# Altium schematic sheet SAR_ADC.SchDoc(SAR_ADC)
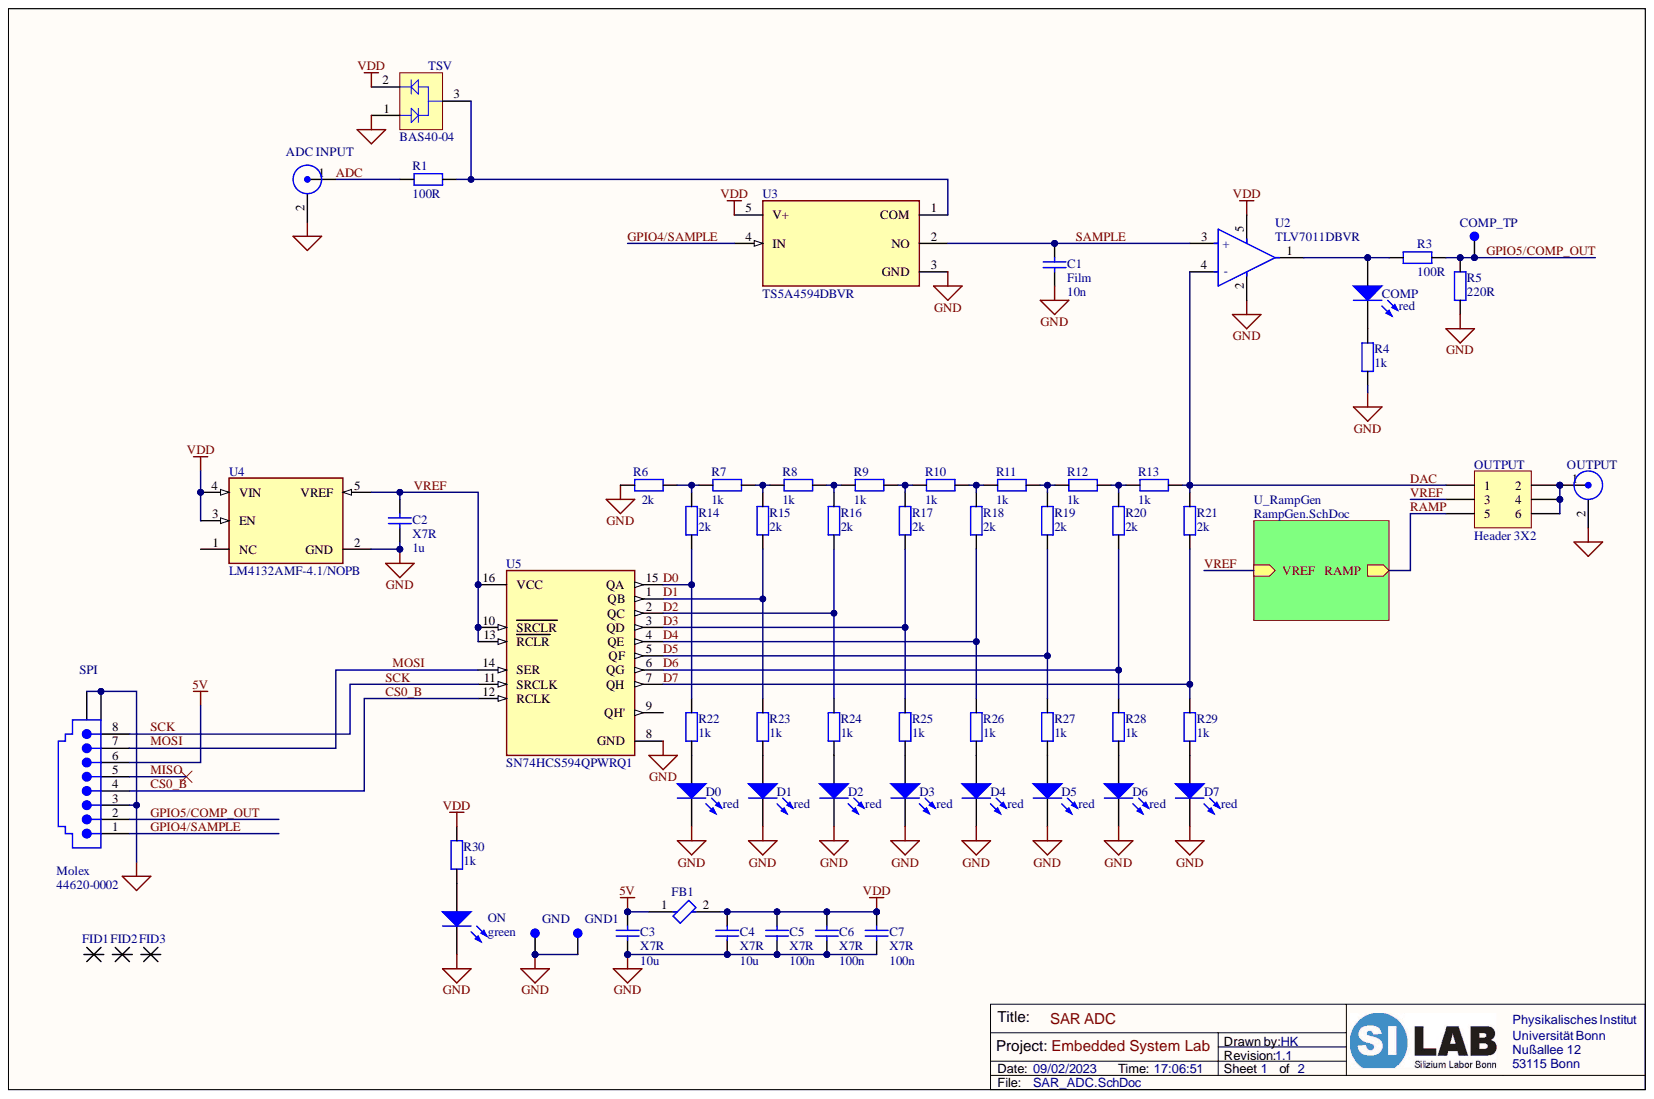
[diagram p0: SAR_ADC.SchDoc]

## Parts
ADC_INPUT  -  [LEMO horiz.]  (value not drawn)
C1  Film  value 10n  [C2220_imp_N]
C2  X7R  value 1u  [C0603_imp_N]
C3  X7R  value 10u  [C0603_imp_N]
C4  X7R  value 10u  [C0603_imp_N]
C5  X7R  value 100n  [C0603_imp_N]
C6  X7R  value 100n  [C0603_imp_N]
C7  X7R  value 100n  [C0603_imp_N]
COMP  red  [0603_A]
COMP_TP  -  [Testpoint 1.0mm]  (value not drawn)
D0  red  [0603_A]
D1  red  [0603_A]
D2  red  [0603_A]
D3  red  [0603_A]
D4  red  [0603_A]
D5  red  [0603_A]
D6  red  [0603_A]
D7  red  [0603_A]
FB1  BLM18PG121SN1D  [FP-BLM18-0_15-t0_8-IPC_A]  (value not drawn)
FID1  xxx  [Fiducial]  (value not drawn)
FID2  xxx  [Fiducial]  (value not drawn)
FID3  xxx  [Fiducial]  (value not drawn)
GND  -  [Testpoint 1.0mm]  (value not drawn)
GND1  -  [Testpoint 1.0mm]  (value not drawn)
ON  green  [0603_A]
OUTPUT  -  [LEMO horiz.]  (value not drawn)
OUTPUT  Header 3X2  [HDR2X3]
R1  R  value 100R  [R0603_imp_N]
R3  R  value 100R  [R0603_imp_N]
R4  R  value 1k  [R0603_imp_N]
R5  R  value 220R  [R0603_imp_N]
R6  R  value 2k  [R0603_imp_N]
R7  R  value 1k  [R0603_imp_N]
R8  R  value 1k  [R0603_imp_N]
R9  R  value 1k  [R0603_imp_N]
R10  R  value 1k  [R0603_imp_N]
R11  R  value 1k  [R0603_imp_N]
R12  R  value 1k  [R0603_imp_N]
R13  R  value 1k  [R0603_imp_N]
R14  R  value 2k  [R0603_imp_N]
R15  R  value 2k  [R0603_imp_N]
R16  R  value 2k  [R0603_imp_N]
R17  R  value 2k  [R0603_imp_N]
R18  R  value 2k  [R0603_imp_N]
R19  R  value 2k  [R0603_imp_N]
R20  R  value 2k  [R0603_imp_N]
R21  R  value 2k  [R0603_imp_N]
R22  R  value 1k  [R0603_imp_N]
R23  R  value 1k  [R0603_imp_N]
R24  R  value 1k  [R0603_imp_N]
R25  R  value 1k  [R0603_imp_N]
R26  R  value 1k  [R0603_imp_N]
R27  R  value 1k  [R0603_imp_N]
R28  R  value 1k  [R0603_imp_N]
R29  R  value 1k  [R0603_imp_N]
R30  R  value 1k  [R0603_imp_N]
SPI  Comment_1: Molex  [44620-0002]  (hidden comment: -)
TSV  BAS40-04  [INF-SOT23_L]
U2  TLV7011DBVR  [FP-DBV0005A-MFG]
U3  TS5A4594DBVR  [FP-DBV0005A-IPC_A]
U4  LM4132AMF-4.1/NOPB  [FP-DBV0005A-IPC_A]
U5  SN74HCS594QPWRQ1  [FP-PW0016A-IPC_A]

## Nets
5V: C3.1 FB1.1 SPI.6
GND: ADC_INPUT.2 C1.2 C2.2 C3.2 C4.2 C5.2 C6.2 C7.2 D0.1 D1.1 D2.1 D3.1 D4.1 D5.1 D6.1 D7.1 GND.1 GND1.1 ON.1 OUTPUT.2 R4.2 R5.2 R6.2 SPI.3 SPI.MH1 SPI.MH2 TSV.1 U2.2 U3.3(GND) U4.2(GND) U5.8(GND)
VDD: C4.1 C5.1 C6.1 C7.1 FB1.2 R30.2 TSV.2 U2.5 U3.5(V+) U4.3(EN) U4.4(VIN)
ADC: ADC_INPUT.1 R1.2
CS0_B: SPI.4 U5.12(RCLK)
D0: R14.2 R22.1 U5.15(QA)
D1: R15.1 R23.2 U5.1(QB)
D2: R16.2 R24.1 U5.2(QC)
D3: R17.2 R25.1 U5.3(QD)
D4: R18.2 R26.1 U5.4(QE)
D5: R19.2 R27.1 U5.5(QF)
D6: R20.2 R28.1 U5.6(QG)
D7: R21.2 R29.1 U5.7(QH)
DAC: OUTPUT.1 R13.1 R21.1 U2.4(-)
GPIO4/SAMPLE: SPI.1 U3.4(IN)
GPIO5/COMP_OUT: COMP_TP.1 R3.2 R5.1 SPI.2
MISO: SPI.5
MOSI: SPI.7 U5.14(SER)
RAMP: OUTPUT.5
SAMPLE: C1.1 U2.3(+) U3.2(NO)
SCK: SPI.8 U5.11(SRCLK)
VREF: C2.1 OUTPUT.3 U4.5(VREF) U5.10(SRCLR) U5.13(RCLR) U5.16(VCC)
NetCOMP_1: COMP.1 R4.1
NetCOMP_2: COMP.2 R3.1 U2.1
NetD0_2: D0.2 R22.2
NetD1_2: D1.2 R23.1
NetD2_2: D2.2 R24.2
NetD3_2: D3.2 R25.2
NetD4_2: D4.2 R26.2
NetD5_2: D5.2 R27.2
NetD6_2: D6.2 R28.2
NetD7_2: D7.2 R29.2
NetON_2: ON.2 R30.1
NetOUTPUT_1: OUTPUT.1 OUTPUT.2 OUTPUT.4 OUTPUT.6
NetR1_1: R1.1 TSV.3 U3.1(COM)
NetR6_1: R6.1 R7.1 R14.1
NetR7_2: R7.2 R8.2 R15.2
NetR8_1: R8.1 R9.2 R16.1
NetR9_1: R9.1 R10.2 R17.1
NetR10_1: R10.1 R11.2 R18.1
NetR11_1: R11.1 R12.2 R19.1
NetR12_1: R12.1 R13.2 R20.1
NetU4_1: U4.1(NC)
NetU5_9: U5.9(QH')

## Library data of the parts
ADC_INPUT OUTPUT = LEMO coax: LEMO/BNC Buchse, aufrecht od. gewinkelt; Class: Connector; Comment_1: LEMO; Type_1: EPL.00.250.NTN; Component Kind: Standard; Constraints: -; HelpURL: -; LatestRevisionDate: 24-Sep-2008; PackageDescription: -; Value: -; Library Name: Silab_Lib.IntLib; Publisher: Silab C.H.
C1-C7 = C: Capacitor; Class: Passive; Constraints: 6.3V; Type_1: SMD Chip Capacitor; Comment_1: -; Component Kind: Standard; HelpURL: -; LatestRevisionDate: 27-Nov-2007; PackageDescription: -; Signal Integrity: Capacitor; Simulation: Capacitor; Library Name: Silab_Lib.IntLib; Publisher: Silab J.S.
COMP D0-D7 = CMP-1426-00001-1: SMD mono-color Chip LED, WL-SMCW, Red; Manufacturer: Wuerth Elektronik; PartNumber: 150060RS75000; Color: Red; Component Kind: Standard; ComponentLink1Description: Manufacturer URL; ComponentLink1URL: http://www.we-online.com; ComponentLink2Description: Datasheet; ComponentLink2URL: http://katalog.we-online.com/led/datasheet/150060RS75000.pdf; Library Name: Altium Content Vault; PackageReference: 0603_A
COMP_TP GND GND1 = Testpoint_gefüllt: Solderpad, Einzelner Kontakt; Class: Connector; Comment_1: -; Component Kind: Standard; Constraints: -; HelpURL: -; LatestRevisionDate: 24-Sep-2008; PackageDescription: -; Type_1: -; Value: -; Library Name: Silab_Lib.IntLib; Publisher: Silab C.H.
FB1 = CMP-0686-00557-7: Chip Ferrite Bead, 0603, 120Ω @ 100MHz, 0.05Ω, 25%, 2A; DC Resistance (DCR): 50mR; Impedance: 120R; Material: Steel; Number of Circuits: 1; Shielding: Unshielded; Case Code (Imperial): 0603; Case Code (Metric): 1608; Case/Package: 0603; Component Kind: Standard; Core Material: Ferrite; Current Rating: 2A; DC Current: 2A; Depth: 0.8mm; Frequency: 100MHz; Height: 0.8mm; Lead Free: Lead Free; Length: 1.6mm; Library Name: Altium Content Vault; Max Current Rating: 2A; Max DC Current: 2A; Max Operating Temperature: 125°C; Min Operating Temperature: -55°C; Number of Lines: 1; Number of Pins: 2; Package Quantity: 1; Packaging: Tape and Reel; Radiation Hardening: No; REACH SVHC: No SVHC; RoHS Compliant: Yes; Termination: SMD/SMT; Test Frequency: 100MHz; Tolerance: 25%; Width: 0.8mm
FID1-FID3 = Fiducial: Class: Passive; Comment_1: xxx; Component Kind: Standard; Constraints: xxx; Description: Fiducial; LatestRevisionDate: 09-Aug-2013; PackageDescription: xxx; Type_1: xxx; Value: xxx; HelpURL: http://www.   ...  or    X:\DXP\Datasheets\ ...; Library Name: Silab_Lib.IntLib; Publisher: Silab VF
ON = CMP-1426-00002-1: SMD mono-color Chip LED, WL-SMCW, Green; Manufacturer: Wuerth Elektronik; PartNumber: 150060GS75000; Color: Green; Component Kind: Standard; ComponentLink1Description: Manufacturer URL; ComponentLink1URL: http://www.we-online.com; ComponentLink2Description: Datasheet; ComponentLink2URL: http://katalog.we-online.com/led/datasheet/150060GS75000.pdf; Library Name: Altium Content Vault; PackageReference: 0603_A
OUTPUT = Header 3X2: Header, 3-Pin, Dual row; Component Kind: Standard; LatestRevisionDate: 17-Jul-2002; LatestRevisionNote: Re-released for DXP Platform.; Library Name: Miscellaneous Connectors.IntLib; Signal Integrity: Connector; Publisher: Altium Limited
R1 R3-R6 R14-R30 = R: SMD Chip Resistor; Class: Passive; Constraints: 1%; Comment_1: -; Component Kind: Standard; HelpURL: -; LatestRevisionDate: 27-Nov-2007; PackageDescription: -; Signal Integrity: Resistor; Simulation: Resistor; Type_1: SMD Chip Resistor; Library Name: Silab_Lib.IntLib; Publisher: Silab J.S.
R7-R13 = R: SMD Chip Resistor; Class: Passive; Constraints: 1%; Value: 1k; Comment_1: -; Component Kind: Standard; HelpURL: -; LatestRevisionDate: 27-Nov-2007; PackageDescription: -; Signal Integrity: Resistor; Simulation: Resistor; Type_1: SMD Chip Resistor; Library Name: Silab_Lib.IntLib; Publisher: Silab J.S.
SPI = RJ-45 Buchse: Modular Jack, Right Angle RJ-45, Low Profile, Inverted, with all Panel Grounding Tabs, Shielded with RJ-11 Keepout Feature; Class: Connector; Component Kind: Standard; Constraints: -; HelpURL: http://www.molex.com/cmc_upload/common_files/1212003_mx44620.pdf; LatestRevisionDate: 24-Sep-2008; Signal Integrity: Modular Jack; Type_1: 44620-0002; Value: -; Library Name: Silab_Lib.IntLib; Publisher: Silab C.H.
TSV = CMP-1182-00024-1: Silicon Schottky Diode, -55 to 150 degC, SOT23, Reel, Green; Manufacturer: Infineon; Configuration: Dual; CT (max): 5Â pF; Green: TRUE; IF (max): 120Â mA; VF (max): 1,000Â mV; VR (max): 40Â V; Component Kind: Standard; ComponentLink1Description: Manufacturer URL; ComponentLink1URL: http://www.infineon.com/; ComponentLink2Description: Datasheet; ComponentLink2URL: http://www.infineon.com/dgdl/bas40_bas140series.pdf?folderId=db3a30431400ef68011425f1ca2505e3&fileId=db3a304314dca389011518a57ed20e11; ComponentLink3Description: Package Specification; ComponentLink3URL: http://www.infineon.com/dgdl/SOT23-Package_Overview.pdf?folderId=db3a30431936bc4b0119532290053c66&fileId=db3a30431936bc4b0119533468123c85; Library Name: Altium Content Vault; Mounting Technology: Surface Mount; PackageDescription: 3-Pin Small Outline Transistor Package, 1.3 x 2.9 mm Body, 0.95 mm Pitch; PackageReference: SOT23; PackageVersion: Apr-08; Packing: Reel; PartNumber: BAS40-04
U2 = CMP-04896-000099-1: VOLTAGE COMPARATOR; Component Kind: Standard; Library Name: Altium Content Vault
U3 = CMP-04892-000098-1: IC SWITCH SPST SOT23-5; High Level Output Current: 40mA; Max Supply Current: 250nA; Max Supply Voltage: 5.5V; Min Supply Voltage: 2V; Nominal Supply Current: 10nA; Number of Bits: 1; On-State Resistance: 8R; Polarity: Non-Inverting; Supply Type: Single; Turn-Off Delay Time: 14ns; Turn-On Delay Time: 17ns; Case/Package: SOT-23-5; Component Kind: Standard; Contact Plating: Gold; Height: 1.15mm; Lead Free: Lead Free; Length: 2.9mm; Library Name: Altium Content Vault; Low Level Output Current: 40mA; Max Operating Temperature: 85°C; Min Operating Temperature: -40°C; Mount: Surface Mount; Number of Channels: 1; Number of Inputs: 1; Number of Outputs: 1; Number of Pins: 5; Operating Supply Current: 10nA; Package Quantity: 1; Packaging: Tape and Reel; Radiation Hardening: No; REACH SVHC: No SVHC; RoHS Compliant: Yes; Series: -; Supply Current: 10nA; Throw Configuration: SPST; Weight: 0.000395oz; Width: 1.6mm
U4 = CMP-04916-000024-1: IC VREF SERIES 0.05% SOT23-5; Dropout Voltage: 175mV; Max Input Voltage: 6V; Max Output Current: 20mA; Max Output Voltage: 4.096V; Max Power Dissipation: 350mW; Min Input Voltage: 2.2V; Nominal Supply Current: 60uA; Temperature Coefficient: 10ppm/°C; Case/Package: SOT-23; Component Kind: Standard; Height: 1.02mm; Lead Free: Lead Free; Length: 2.92mm; Library Name: Altium Content Vault; Max Operating Temperature: 125°C; Min Operating Temperature: -40°C; Mount: Surface Mount; Number of Pins: 5; Output Current: 20mA; Output Voltage: 4.096V; Package Quantity: 1000; Packaging: Tape and Reel; Quiescent Current: 60uA; Radiation Hardening: No; REACH SVHC: No SVHC; Reference Type: Series; Reference Voltage: 4.096V; RoHS Compliant: Yes; Series: LM4132; Supply Current: 60uA; Termination: SMD/SMT; Tolerance: 0.05%; Width: 1.6mm
U5 = CMP-04904-000006-1: AUTOMOTIVE 8-BIT SHIFT REGISTERS; Component Kind: Standard; Library Name: Altium Content Vault
